# Altium schematic sheet Power.SchDoc(Power)
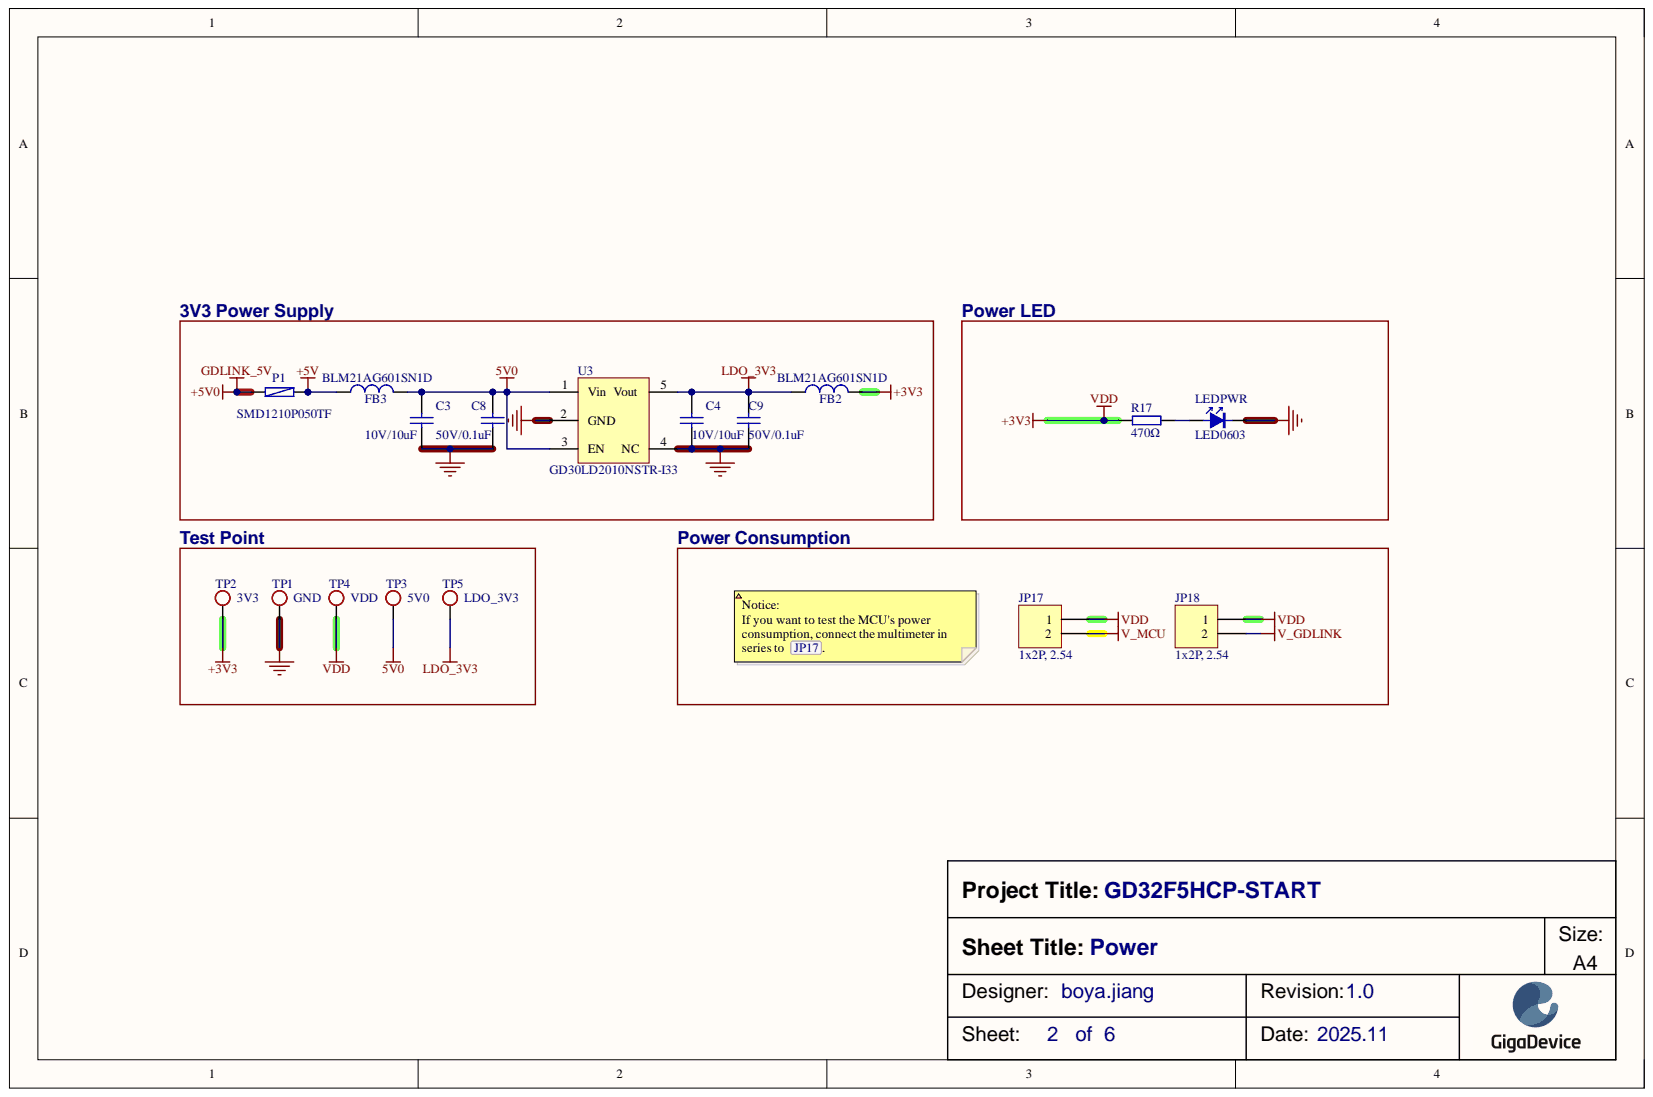
[diagram p0: Power.SchDoc]

## Parts
C3  10V/10uF  [1206-C]
C4  10V/10uF  [1206-C]
C8  50V/0.1uF  [0603-C]
C9  50V/0.1uF  [0603-C]
FB2  BLM21AG601SN1D  [0805-R]
FB3  BLM21AG601SN1D  [0805-R]
JP17  1x2P, 2.54  [SIP-2]
JP18  1x2P, 2.54  [SIP-2]
LEDPWR  NCD0603C1(黄绿)  [0603-LED-Green]  (value not drawn)
P1  SMD1210P050TF  [1210_R]
R17  470Ω  [0603-R]
TP1  TP FOR: GND  [SIP-1, TP]  (hidden comment: 5003)  (no BOM)
TP2  TP FOR: 3V3  [SIP-1, TP]  (hidden comment: 5003)  (no BOM)
TP3  TP FOR: 5V0  [SIP-1, TP]  (hidden comment: 5003)  (no BOM)
TP4  TP FOR: VDD  [SIP-1, TP]  (hidden comment: 5003)  (no BOM)
TP5  TP FOR: LDO_3V3  [SIP-1, TP]  (hidden comment: 5003)  (no BOM)
U3  GD30LD2010NSTR-I33  [SOT-23-5]

## Nets
5V0: C3.2 C8.2 FB3.1 FB3.2 P1.1 P1.2 TP3.1 U3.1(Vin) U3.3(EN)
+3V3: FB2.2 JP17.1 JP18.1 R17.1 TP2.1 TP4.1
GND: C3.1 C4.1 C8.1 C9.1 LEDPWR.K TP1.1 U3.2(GND) U3.4(NC)
LDO_3V3: C4.2 C9.2 FB2.1 TP5.1 U3.5(Vout)
V_GDLINK: JP18.2
V_MCU: JP17.2
NetLEDPWR_A: LEDPWR.A R17.2

## Library data of the parts
C3 C4 = 16V/10uF: 表贴电容; Component Kind: Standard; Library Name: KLTC-电容.SchLib
C8 C9 = 50V/0.1uF: 表贴电容; Component Kind: Standard; Library Name: KLTC-电容.SchLib
FB2 FB3 = BLM21AG221SN1D: 表贴磁珠, ±25%,  600Ω@100MHz, DCR:210mΩ, 700mA; Component Kind: Standard; Library Name: KLTC-电感及磁珠.SchLib
JP17 JP18 = HEADER 2_1: 单排插针; Component Kind: Standard; Library Name: KLTC-按键及接插件.SchLib
LEDPWR = LED0603: 表贴LED发光管; Component Kind: Standard; Footprint For: LED0603; Library Name: xufei.SchLib
P1 = SMD1210P005TF: 表贴PTC; Component Kind: Standard; Library Name: KLTC-保护元件.SchLib
R17 = 470Ω: 表贴电阻; Component Kind: Standard; Library Name: KLTC-电阻.SchLib
TP1-TP5 = HEADER 1: 弹簧探针连接器, 测试点; Library Name: boyajiang.SchLib
U3 = TPS7A2033PDBVR: 表贴LDO芯片, 0.8~5V, 500mA; Note: GD供料; Component Kind: Standard; Library Name: Temp.SchLib
